# Altium schematic sheet MCU.SchDoc
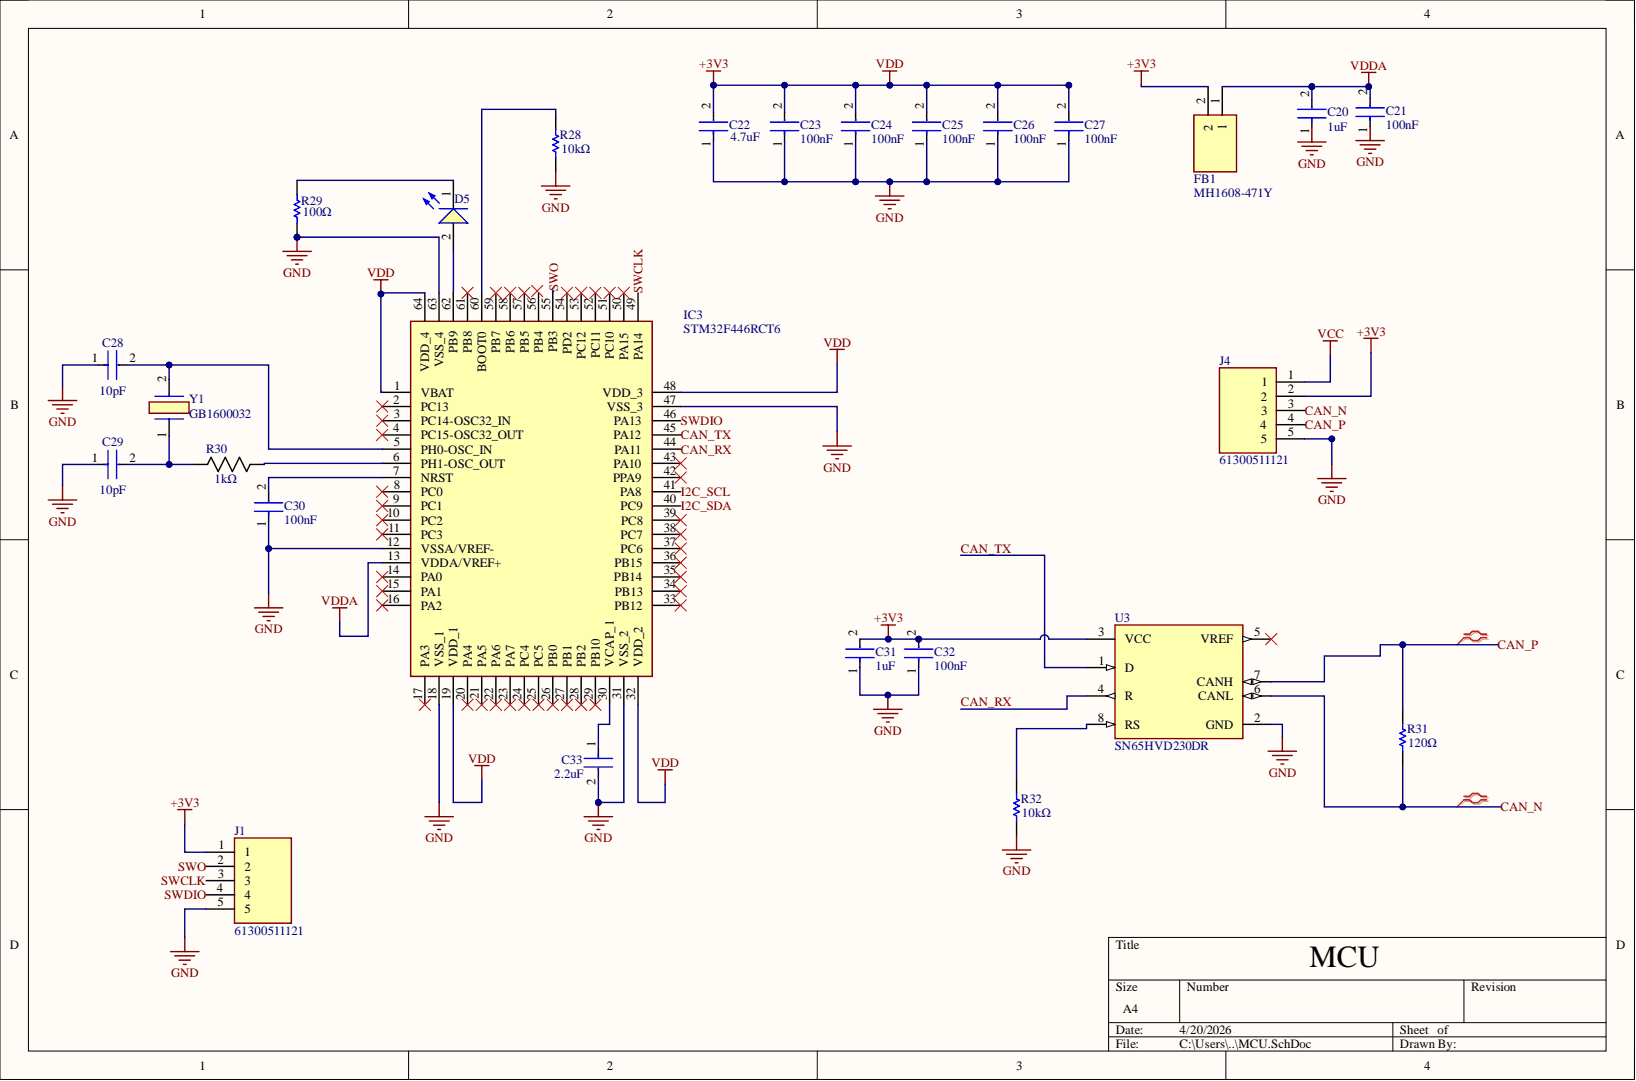
[diagram p0: MCU.SchDoc]

## Parts
C20  1uF  [FP-0603-L_1_6_0_1-W_0_8_0-IPC_A]
C21  Capacitance: 100nF  [FP-0603-L_1_6_0_1-W_0_8_0-IPC_A]  (hidden comment: 0.1uiF)
C22  4.7uF  [FP-0603-L_1_6_0_1-W_0_8_0-IPC_A]
C23  Capacitance: 100nF  [FP-0603-L_1_6_0_1-W_0_8_0-IPC_A]  (hidden comment: 0.1uiF)
C24  Capacitance: 100nF  [FP-0603-L_1_6_0_1-W_0_8_0-IPC_A]  (hidden comment: 0.1uiF)
C25  Capacitance: 100nF  [FP-0603-L_1_6_0_1-W_0_8_0-IPC_A]  (hidden comment: 0.1uiF)
C26  Capacitance: 100nF  [FP-0603-L_1_6_0_1-W_0_8_0-IPC_A]  (hidden comment: 0.1uiF)
C27  Capacitance: 100nF  [FP-0603-L_1_6_0_1-W_0_8_0-IPC_A]  (hidden comment: 0.1uiF)
C28  10pF  [FP-0603-L_1_6_0_1-W_0_8_0-IPC_A]
C29  10pF  [FP-0603-L_1_6_0_1-W_0_8_0-IPC_A]
C30  Capacitance: 100nF  [FP-0603-L_1_6_0_1-W_0_8_0-IPC_A]  (hidden comment: 0.1uiF)
C31  1uF  [FP-0603-L_1_6_0_15-W_0_8-IPC_B]
C32  Capacitance: 100nF  [FP-0603-L_1_6_0_1-W_0_8_0-IPC_A]  (hidden comment: 0.1uiF)
C33  2.2uF  [FP-0603-L_1_6_0_1-W_0_8_0-IPC_A]
D5  150060YS75000  [WL-SMCW_0603_150060xx75000]  (value not drawn)
FB1  MH1608-471Y  [BLM18SP221SN1B]
IC3  STM32F446RCT6  [QFP50P1200X1200X160-64N]
J1  61300511121  [HDRV5W64P0X254_1X5_1270X254X854P]
J4  61300511121  [HDRV5W64P0X254_1X5_1270X254X854P]
R28  10k  Resistance: 10kΩ  [RESC3216X60X45ML10T20]
R29  100  Resistance: 100Ω  [RESC1608X55X30ML20T10]
R30  Resistance: 1kΩ  [RES_AC01_VIS]  (hidden comment: AC01000001001JA100)
R31  Resistance: 120Ω  [RESC3216X60X45ML10T20]  (hidden comment: 10k)
R32  10k  Resistance: 10kΩ  [RESC3216X60X45ML10T20]
U3  SN65HVD230DR  Case/Package: D  [FP-D0008A-IPC_A]
Y1  GB1600032  [GB1600032]

## Nets
+3V3: C22.2 C23.2 C24.2 C25.2 C26.2 C27.2 C31.2 C32.2 FB1.2 IC3.1(VBAT) IC3.19(VDD_1) IC3.32(VDD_2) IC3.48(VDD_3) IC3.64(VDD_4) J1.1 J4.2 U3.3(VCC)
GND: C20.1 C21.1 C22.1 C23.1 C24.1 C25.1 C26.1 C27.1 C28.1 C29.1 C30.1 C31.1 C32.1 C33.2 IC3.12(VSSA/VREF-) IC3.18(VSS_1) IC3.31(VSS_2) IC3.47(VSS_3) IC3.63(VSS_4) J1.5 J4.5 R28.1 R29.2 R32.1 U3.2(GND)
VCC: J4.1
VDDA: C20.2 C21.2 FB1.1 IC3.13(VDDA/VREF+)
CAN_N: J4.3 R31.1 U3.6(CANL)
CAN_P: J4.4 R31.2 U3.7(CANH)
CAN_RX: IC3.44(PA11) U3.4(R)
CAN_TX: IC3.45(PA12) U3.1(D)
I2C_SCL: IC3.41(PA8)
I2C_SDA: IC3.40(PC9)
SWCLK: IC3.49(PA14) J1.3
SWDIO: IC3.46(PA13) J1.4
SWO: IC3.55(PB3) J1.2
NetC28_2: C28.2 IC3.5(PH0-OSC_IN) Y1.2
NetC29_2: C29.2 R30.1 Y1.1
NetC30_2: C30.2 IC3.7(NRST)
NetC33_1: C33.1 IC3.30(VCAP_1)
NetD5_1: D5.1 R29.1
NetD5_2: D5.2 IC3.62(PB9)
NetIC3_6: IC3.6(PH1-OSC_OUT) R30.2
NetIC3_60: IC3.60(BOOT0) R28.2
NetR32_2: R32.2 U3.8(RS)

Not connected: IC3 2(PC13) 3(PC14-OSC32_IN) 4(PC15-OSC32_OUT) 8(PC0) 9(PC1) 10(PC2) 11(PC3) 14(PA0) 15(PA1) 16(PA2) 17(PA3) 20(PA4) 21(PA5) 22(PA6) 23(PA7) 24(PC4) 25(PC5) 26(PB0) 27(PB1) 28(PB2) 29(PB10) 33(PB12) 34(PB13) 35(PB14) 36(PB15) 37(PC6) 38(PC7) 39(PC8) 42(PPA9) 43(PA10) 50(PA15) 51(PC10) 52(PC11) 53(PC12) 54(PD2) 56(PB4) 57(PB5) 58(PB6) 59(PB7) 61(PB8); U3 5(VREF)

## Library data of the parts
C20-C30 C32 C33 = CMP-14477-003254-2: None; Dielectric Material: Ceramic; Tolerance: 10%; Voltage: 50V; Case Code (Imperial): 0603; Case Code (Metric): 1608; Case/Package: 0603; Component Kind: Standard; Construction: Flat; Depth: 0.8mm; Halogen Free: Halogen Free; Height: 0.8mm; Lead Free: Lead Free; Length: 1.6mm; Library Name: Altium Content Vault; Max Operating Temperature: 125°C; Min Operating Temperature: -55°C; Mount: Surface Mount; Number of Pins: 2; Package Quantity: 10; Packaging: Tape and Reel; Radiation Hardening: No; RoHS Compliant: Yes; Series: CFCAP™; Termination: SMD/SMT; Thickness: 0.035inch; Voltage Rating: 50V; Voltage Rating (DC): 50V; Weight: 7.1E-05oz; Width: 0.031inch
  C20: Capacitance: 1uF
  C22: Capacitance: 4.7uF
  C28 C29: Capacitance: 10pF
  C33: Capacitance: 2.2uF
C31 = CMP-2000-07531-4: Ceramic Capacitor General Use 1uF ±10% 50V X7R 0603; Capacitance: 1uF; Case Code (Imperial): 0603; Case Code (Metric): 1608; Case/Package: 0603; Component Kind: Standard; Construction: Flat; Depth: 0.8mm; Dielectric: X7R; Halogen Free: Halogen Free; Height: 0.8mm; Length: 1.6mm; Library Name: Altium Content Vault; Max Operating Temperature: 125°C; Min Operating Temperature: -55°C; Mount: Surface Mount; Package Quantity: 1; Packaging: Tape and Reel; Radiation Hardening: No; RoHS Compliant: Yes; Series: M; Termination: SMD/SMT; Thickness: 0.037inch; Tolerance: 10%; Voltage Rating: 50V; Voltage Rating (DC): 50V; Width: 0.031inch
D5 = CMP-1426-00005-2: NexarPartId: 29687085; Color: Yellow; Dominant Wavelength: 590nm; Forward Current: 100mA; Forward Voltage: 2V; Lens Style: Clear, Rectangular; Luminous Intensity: 120mcd; Max Junction Temperature (Tj): 95°C; Max Reverse Voltage (DC): 5V; Peak Wavelength: 595nm; Power Dissipation: 72mW; Test Current: 20mA; Viewing Angle: 140°; Case Code (Imperial): 0603; Case Code (Metric): 1608; Case/Package: 0603; Component Kind: Standard; Height: 700µm; Length: 1.6mm; Library Name: Altium Content Vault; Max Operating Temperature: 85°C; Min Operating Temperature: -40°C; Mount: Surface Mount; Packaging: Tape and Reel; REACH SVHC: Unknown; Reverse Voltage: 5V; RoHS: Compliant; Wavelength: 590nm; Width: 800µm
FB1 = BLM18KG331SN1D: Ferrite Bead; Manufacturer_Name: Bourns; Component Kind: Standard; Datasheet Link: https://au.mouser.com/datasheet/2/54/mh-777565.pdf; Height: 0.8mm; Manufacturer_Part_Number: BLM18KG331SN1D; Mouser Part Number: 652-MH1608-471Y
IC3 = STM32F446RCT6: Integrated Circuit; Manufacturer_Name: STMicroelectronics; Arrow Part Number: STM32F446RCT6; Arrow Price/Stock: https://www.arrow.com/en/products/stm32f446rct6/stmicroelectronics?region=europe; Component Kind: Standard; Datasheet Link: http://www.st.com/content/ccc/resource/technical/document/datasheet/65/cb/75/50/53/d6/48/24/DM00141306.pdf/files/DM00141306.pdf/jcr:content/translations/en.DM00141306.pdf; Height: 1.6mm; Manufacturer_Part_Number: STM32F446RCT6; Mouser Part Number: 511-STM32F446RCT6; Mouser Price/Stock: https://www.mouser.co.uk/ProductDetail/STMicroelectronics/STM32F446RCT6?qs=Ok1pvOkw6%2FrlZ63GppV%2FkA%3D%3D
J1 J4 = 61300511121: Connector; Manufacturer_Name: Wurth Elektronik; Component Kind: Standard; Datasheet Link: http://katalog.we-online.de/em/datasheet/6130xx11121.pdf; Height: 8.54mm; Manufacturer_Part_Number: 61300511121; Mouser Part Number: 710-61300511121; Mouser Price/Stock: https://www.mouser.co.uk/ProductDetail/Wurth-Elektronik/61300511121?qs=PhR8RmCirEZCsCRHq%252BvfRQ%3D%3D
R28 = CMP-2003-02052-1: 0603 Resistor; Manufacturer: Vishay Dale; Part Number: CRCW12062K74FKTA; Component Kind: Standard; Library Name: Altium Content Vault
R29 = CMP-2000-01849-1: 0603 Resistor; Manufacturer: KOA Speer; Part Number: RK73B1JTTD101J; Component Kind: Standard; Library Name: Altium Content Vault
R30 = CMP-T1-00030-42521882-4: VISHAY AC01000001001JA100 Through Hole Resistor, 1 kohm, Axial Leaded, 1 W, 5%, AC Series; Lead Diameter: 800µm; Max Power Dissipation: 1W; Temperature Coefficient: 180ppm/°C; Voltage Rating (DC): 32V; Case/Package: Axial; Component Kind: Standard; Composition: Wirewound; Contact Plating: Tin; Diameter: 4.3mm; Features: Flame Retardant Coating; Lead Free: Lead Free; Length: 11mm; Library Name: Altium Content Vault; Max Operating Temperature: 275°C; Military Standard: Not; Min Operating Temperature: -40°C; NexarPartId: 42521882; Number of Pins: 2; Number of Terminations: 2; Packaging: Tape & Box (TB); Power Rating: 1W; Radiation Hardening: No; REACH SVHC: Unknown; RoHS: Compliant; Schedule B: 8533210080, 8533210080; Termination: Axial; Tolerance: 5%
R31 R32 = CMP-2003-02052-1: 1206 Resistor; Manufacturer: Vishay Dale; Part Number: CRCW12062K74FKTA; Component Kind: Standard; Library Name: Altium Content Vault
U3 = CMP-0315-00015-3: IC TRANSCEIVER HALF 1/1 8SOIC; Data Rate: 1Mbps; Max Supply Current: 17mA; Max Supply Voltage: 3.6V; Min Supply Voltage: 3V; Number of Drivers: 1; Number of I/Os: 2; Operating Supply Voltage: 3.3V; Component Kind: Standard; Contact Plating: Gold; Lead Free: Lead Free; Library Name: Altium Content Vault; Max Operating Temperature: 85°C; Min Operating Temperature: -40°C; Nominal Supply Current: 17mA; Number of Pins: 8; Number of Receive Buffers: 1; Number of Receivers: 1; Number of Transceivers: 1; Number of Transmit Buffers: 1; Package Quantity: 1; Packaging: Tape and Reel; Radiation Hardening: No; REACH SVHC: No SVHC; Termination: SMD/SMT; Weight: 0.002561oz
Y1 = GB1600032: Crystal or Oscillator; Manufacturer_Name: Diodes Incorporated; Arrow Part Number: GB1600032; Arrow Price/Stock: https://www.arrow.com/en/products/gb1600032/diodes-incorporated; Component Kind: Standard; Datasheet Link: https://www.diodes.com/assets/Datasheets/GB_GG.pdf; Height: 3.5mm; Manufacturer_Part_Number: GB1600032; Mouser Part Number: 729-GB1600032; Mouser Price/Stock: https://www.mouser.co.uk/ProductDetail/Diodes-Incorporated/GB1600032?qs=%252BkPSyx%252BVsdXQGx7yW5QABw%3D%3D
